# Altium schematic sheet JacdacNRF52833HighPower 32.SchDoc
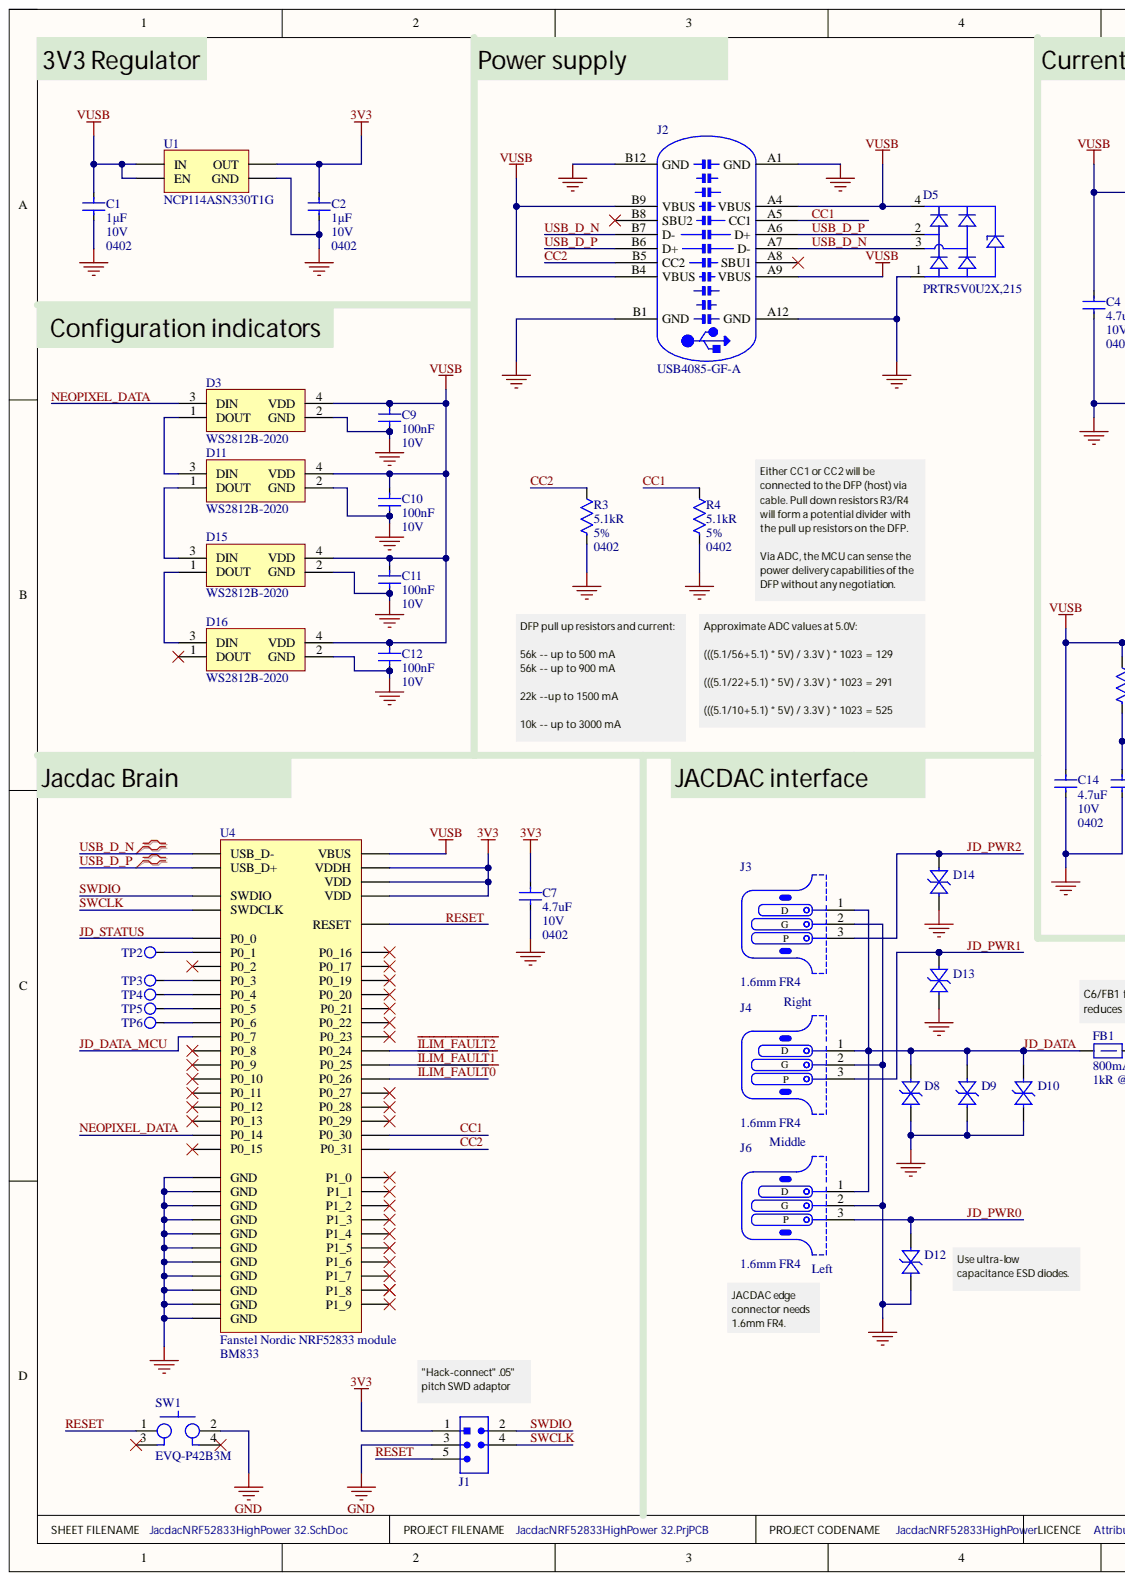
[diagram p0: JacdacNRF52833HighPower 32.SchDoc, left]
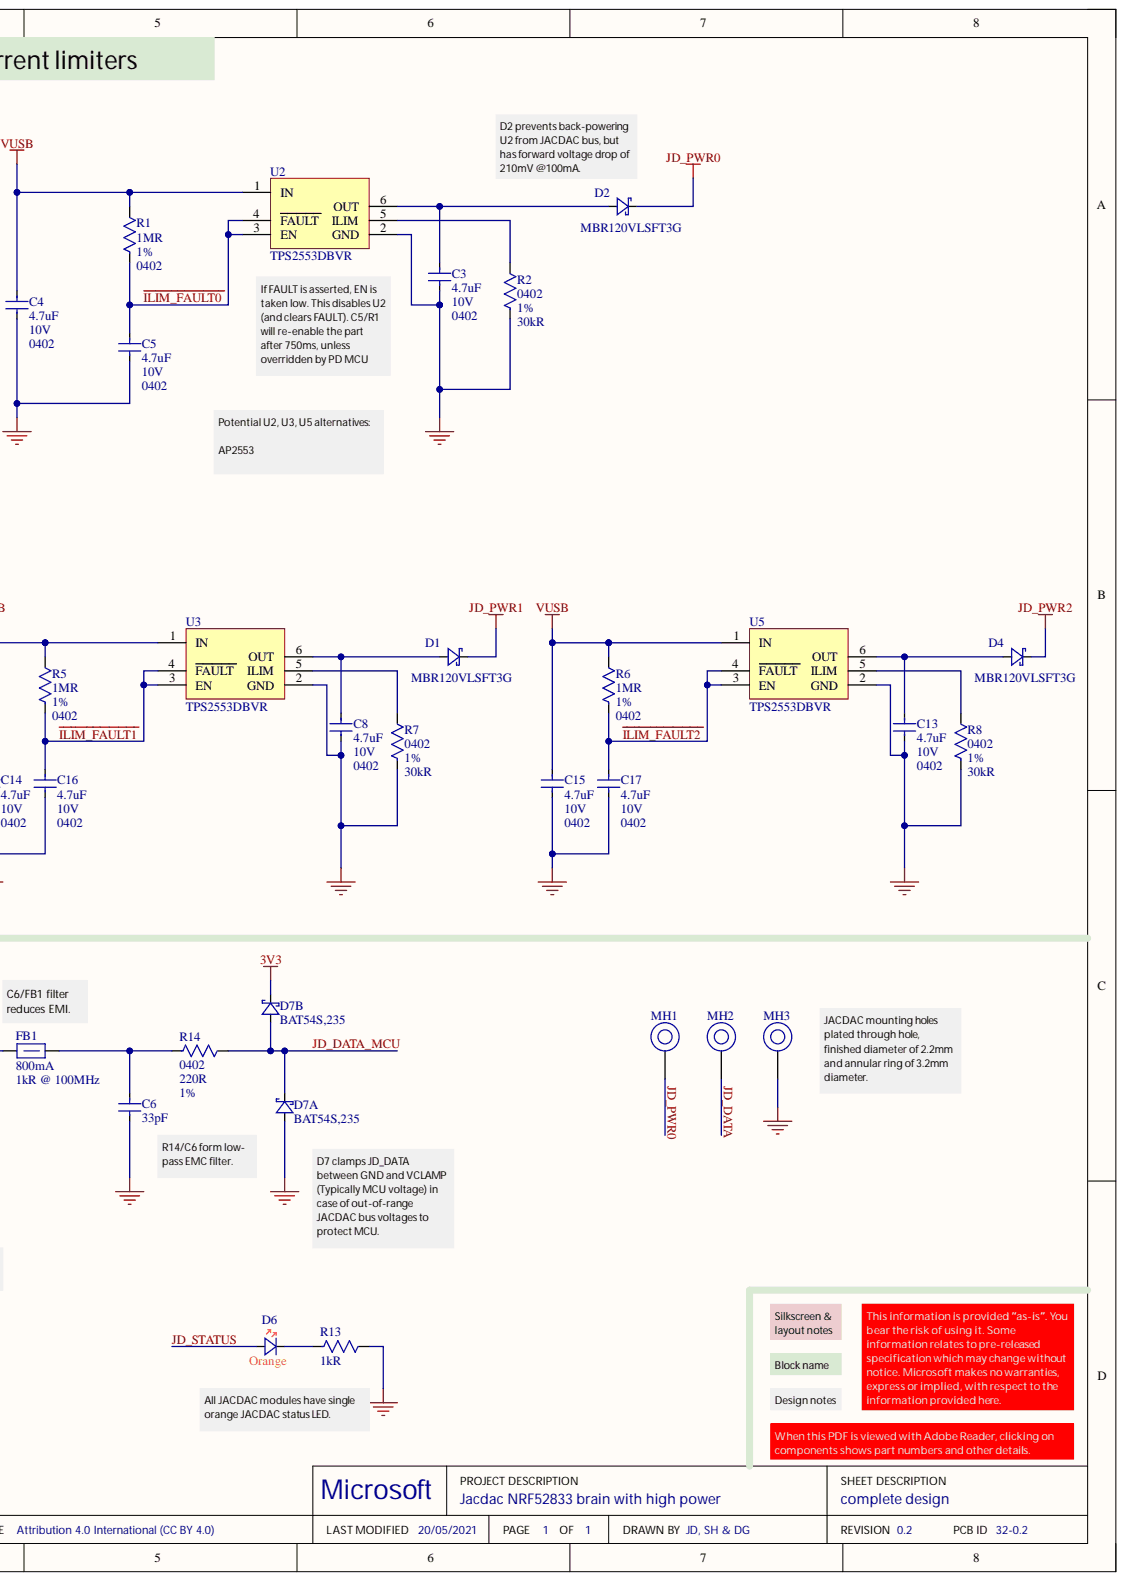
[diagram p1: JacdacNRF52833HighPower 32.SchDoc, right]

## Parts
C1  Capacitance: 1µF  Case Code (Imperial): 0402  Voltage Rating: 10V  [C0402_0.55MM - without outline]  (hidden comment: CL05A105KP5NNNC)
C2  Capacitance: 1µF  Case Code (Imperial): 0402  Voltage Rating: 10V  [C0402_0.55MM - without outline]  (hidden comment: CL05A105KP5NNNC)
C3  Capacitance: 4.7uF  Case Code (Imperial): 0402  Voltage Rating: 10V  [R0402 - Without outline]  (hidden comment: CL05A475MP5NRNC)
C4  Capacitance: 4.7uF  Case Code (Imperial): 0402  Voltage Rating: 10V  [R0402 - Without outline]  (hidden comment: CL05A475MP5NRNC)
C5  Capacitance: 4.7uF  Case Code (Imperial): 0402  Voltage Rating: 10V  [R0402 - Without outline]  (hidden comment: CL05A475MP5NRNC)
C6  Capacitance: 33pF  [C0402_0.55MM - without outline]  (hidden comment: CL05C330JB51PNC)
C7  Capacitance: 4.7uF  Case Code (Imperial): 0402  Voltage Rating: 10V  [R0402 - Without outline]  (hidden comment: CL05A475MP5NRNC)
C8  Capacitance: 4.7uF  Voltage Rating: 10V  [R0402 - Without outline]  (hidden comment: CL05A475MP5NRNC)
C9  Capacitance: 100nF  Voltage Rating: 10V  [C0402_0.55MM - without outline]  (hidden comment: CL05B104KP5NNNC)
C10  Capacitance: 100nF  Voltage Rating: 10V  [C0402_0.55MM - without outline]  (hidden comment: CL05B104KP5NNNC)
C11  Capacitance: 100nF  Voltage Rating: 10V  [C0402_0.55MM - without outline]  (hidden comment: CL05B104KP5NNNC)
C12  Capacitance: 100nF  Voltage Rating: 10V  [C0402_0.55MM - without outline]  (hidden comment: CL05B104KP5NNNC)
C13  Capacitance: 4.7uF  Voltage Rating: 10V  [R0402 - Without outline]  (hidden comment: CL05A475MP5NRNC)
C14  Capacitance: 4.7uF  Case Code (Imperial): 0402  Voltage Rating: 10V  [R0402 - Without outline]  (hidden comment: CL05A475MP5NRNC)
C15  Capacitance: 4.7uF  Case Code (Imperial): 0402  Voltage Rating: 10V  [R0402 - Without outline]  (hidden comment: CL05A475MP5NRNC)
C16  Capacitance: 4.7uF  Case Code (Imperial): 0402  Voltage Rating: 10V  [R0402 - Without outline]  (hidden comment: CL05A475MP5NRNC)
C17  Capacitance: 4.7uF  Case Code (Imperial): 0402  Voltage Rating: 10V  [R0402 - Without outline]  (hidden comment: CL05A475MP5NRNC)
D1  MBR120VLSFT3G  [MBR120VLSFT3G]
D2  MBR120VLSFT3G  [MBR120VLSFT3G]
D3  WS2812B-2020  [WS2812B-2020]
D4  MBR120VLSFT3G  [MBR120VLSFT3G]
D5  Manufacturer Part Number: PRTR5V0U2X,215  [NXP-SOT143B-4_V]  (hidden comment: NXP-PRTR5V0U2X,215-4-whitesch)
D6  Illumination Color: Orange  [LTST-S220KFKT]  (hidden comment: Right angle mount LED (orange))
D7  BAT54S  Manufacturer Part Number: BAT54S,235  [NXP-BAT54S-TO-236AB]  (parts A,B)
D8  VBUS05B1-SD0-G4-08  [0201-VBUS05B1-SD0-G4-08 - Without outline]  (value not drawn)
D9  VBUS05B1-SD0-G4-08  [0201-VBUS05B1-SD0-G4-08 - Without outline]  (value not drawn)
D10  VBUS05B1-SD0-G4-08  [0201-VBUS05B1-SD0-G4-08 - Without outline]  (value not drawn)
D11  WS2812B-2020  [WS2812B-2020]
D12  VBUS05B1-SD0-G4-08  [0201-VBUS05B1-SD0-G4-08 - Without outline]  (value not drawn)
D13  VBUS05B1-SD0-G4-08  [0201-VBUS05B1-SD0-G4-08 - Without outline]  (value not drawn)
D14  VBUS05B1-SD0-G4-08  [0201-VBUS05B1-SD0-G4-08 - Without outline]  (value not drawn)
D15  WS2812B-2020  [WS2812B-2020]
D16  WS2812B-2020  [WS2812B-2020]
FB1  Current Rating: 800mA  Impedance: 1kR @ 100MHz  [TDK MPZ1608 0.8mm - without outline]  (hidden comment: TDK MPZ1608S102ATA00)
J1  Hack Connect Mini 1.27 mm pitch 2-row  [HACK_CONNECT_MINI_5_X_1_27]  (value not drawn)  (no BOM)
J2  USB4085-GF-A  [USB4085-GF-A]
J3  JACDAC edge connector  PCB Type: 1.6mm FR4  [JACDAC_EDGE_CONNECTOR_0.2mm_T&G]  (no BOM)
J4  JACDAC edge connector  PCB Type: 1.6mm FR4  [JACDAC_EDGE_CONNECTOR_0.2mm_T&G]  (no BOM)
J6  JACDAC edge connector  PCB Type: 1.6mm FR4  [JACDAC_EDGE_CONNECTOR_0.2mm_T&G]  (no BOM)
MH1  MH_5.0MMK_3.0MMC_2.1MMD_PTH  [MH_5.0MMK_3.0MMC_2.1MMD_PTH]  (value not drawn)  (no BOM)
MH2  MH_5.0MMK_3.0MMC_2.1MMD_PTH  [MH_5.0MMK_3.0MMC_2.1MMD_PTH]  (value not drawn)  (no BOM)
MH3  MH_5.0MMK_3.0MMC_2.1MMD_PTH  [MH_5.0MMK_3.0MMC_2.1MMD_PTH]  (value not drawn)  (no BOM)
R1  Case Code (Imperial): 0402  Resistance: 1MR  Resistance Tolerance: 1%  [R0402 - Without outline]
R2  Case Code (Imperial): 0402  Resistance: 30kR  Resistance Tolerance: 1%  [R0402]  (hidden comment: 0402 RES SMD 30k 1%)
R3  Case Code (Imperial): 0402  Resistance: 5.1kR  Resistance Tolerance: 5%  [R0402 - Without outline]  (hidden comment: RC0402JR-075K1L)
R4  Case Code (Imperial): 0402  Resistance: 5.1kR  Resistance Tolerance: 5%  [R0402 - Without outline]  (hidden comment: RC0402JR-075K1L)
R5  Case Code (Imperial): 0402  Resistance: 1MR  Resistance Tolerance: 1%  [R0402 - Without outline]
R6  Case Code (Imperial): 0402  Resistance: 1MR  Resistance Tolerance: 1%  [R0402 - Without outline]
R7  Case Code (Imperial): 0402  Resistance: 30kR  Resistance Tolerance: 1%  [R0402]  (hidden comment: 0402 RES SMD 30k 1%)
R8  Case Code (Imperial): 0402  Resistance: 30kR  Resistance Tolerance: 1%  [R0402]  (hidden comment: 0402 RES SMD 30k 1%)
R13  Resistance: 1kR  [R0402 - Without outline]  (hidden comment: RC0402FR-071KL)
R14  Case Code (Imperial): 0402  Resistance: 220R  Tolerance: 1%  [R0402 - Without outline]  (hidden comment: RC0402FR-07220RL)
SW1  Side mount digital switch.  [EVQ-P42B3M]  (value not drawn)
TP2  TestPoint  [Pad1.27mm]  (value not drawn)  (no BOM)
TP3  TestPoint  [Pad1.27mm]  (value not drawn)  (no BOM)
TP4  TestPoint  [Pad1.27mm]  (value not drawn)  (no BOM)
TP5  TestPoint  [Pad1.27mm]  (value not drawn)  (no BOM)
TP6  TestPoint  [Pad1.27mm]  (value not drawn)  (no BOM)
U1  LDO 3.3V/300 mA  [ON_SEMI-SOT23-5]  (value not drawn)
U2  Manufacturer Part Number 1: TPS2553DBVR  [TI-TPS2553]  (hidden comment: TPS2553DBVR_ALT)
U3  Manufacturer Part Number 1: TPS2553DBVR  [TI-TPS2553]  (hidden comment: TPS2553DBVR_ALT)
U4  Fanstel Nordic NRF52833 module  Manufacturer 1: Fanstel  [BM833]
U5  Manufacturer Part Number 1: TPS2553DBVR  [TI-TPS2553]  (hidden comment: TPS2553DBVR_ALT)

## Nets
3V3: C2.2 C7.2 D7.2 J1.1 U1.5(OUT) U4.14(VDD) U4.28(VDD) U4.56(VDDH)
GND: C1.1 C2.1 C3.1 C4.1 C5.1 C6.1 C7.1 C8.1 C9.1 C10.1 C11.1 C12.1 C13.1 C14.1 C15.1 C16.1 C17.1 D3.2(GND) D5.1 D7.1 D8.2 D9.2 D10.2 D11.2(GND) D12.2 D13.2 D14.2 D15.2(GND) D16.2(GND) J1.3 J2.A1(GND) J2.A12(GND) J2.B1(GND) J2.B12(GND) J3.2 J4.2 J6.2 MH3.1 R2.1 R3.2 R4.2 R7.1 R8.1 R13.1 SW1.2 U1.2(GND) U2.2(GND) U3.2(GND) U4.1(GND) U4.2(GND) U4.3(GND) U4.4(GND) U4.5(GND) U4.13(GND) U4.15(GND) U4.18(GND) U4.19(GND) U4.30(GND) U4.45(GND) U5.2(GND)
VUSB: C1.2 C4.2 C9.2 C10.2 C11.2 C12.2 C14.2 C15.2 D3.4(VDD) D5.4 D11.4(VDD) D15.4(VDD) D16.4(VDD) J2.A4(VBUS) J2.A9(VBUS) J2.B4(VBUS) J2.B9(VBUS) R1.1 R5.1 R6.1 U1.1(IN) U1.3(EN) U2.1(IN) U3.1(IN) U4.58(VBUS) U5.1(IN)
~{ILIM_FAULT0}: C5.2 R1.2 U2.3(EN) U2.4(FAULT) U4.7(P0_26)
~{ILIM_FAULT1}: C16.2 R5.2 U3.3(EN) U3.4(FAULT) U4.55(P0_25)
~{ILIM_FAULT2}: C17.2 R6.2 U4.47(P0_24) U5.3(EN) U5.4(FAULT)
CC1: J2.A5(CC1) R4.1 U4.11(P0_30)
CC2: J2.B5(CC2) R3.1 U4.12(P0_31)
JD_DATA: D8.1 D9.1 D10.1 FB1.1 J3.1 J4.1 J6.1 MH2.1
JD_DATA_MCU: D7.3 R14.1 U4.24(P0_7)
JD_PWR0: D2.1 D12.1 J6.3 MH1.1
JD_PWR1: D1.1 D13.1 J4.3
JD_PWR2: D4.1 D14.1 J3.3
JD_STATUS: D6.2 U4.16(P0_0)
NEOPIXEL_DATA: D3.3(DIN) U4.33(P0_14)
RESET: J1.5 SW1.1 U4.40(RESET)
SWCLK: J1.4 U4.42(SWDCLK)
SWDIO: J1.2 U4.43(SWDIO)
USB_D_N: D5.3 J2.A7(D-) J2.B7(D-) U4.68(USB_D-)
USB_D_P: D5.2 J2.A6(D+) J2.B6(D+) U4.67(USB_D+)
NetC3_2: C3.2 D2.2 U2.6(OUT)
NetC6_2: C6.2 FB1.2 R14.2
NetC8_2: C8.2 D1.2 U3.6(OUT)
NetC13_2: C13.2 D4.2 U5.6(OUT)
NetD3_1: D3.1(DOUT) D11.3(DIN)
NetD6_1: D6.1 R13.2
NetD11_1: D11.1(DOUT) D15.3(DIN)
NetD15_1: D15.1(DOUT) D16.3(DIN)
NetR2_2: R2.2 U2.5(ILIM)
NetR7_2: R7.2 U3.5(ILIM)
NetR8_2: R8.2 U5.5(ILIM)
NetTP2_1: TP2.1 U4.17(P0_1)
NetTP3_1: TP3.1 U4.20(P0_3)
NetTP4_1: TP4.1 U4.21(P0_4)
NetTP5_1: TP5.1 U4.23(P0_5)
NetTP6_1: TP6.1 U4.25(P0_6)

Not connected: D16 1(DOUT); J2 A8(SBU1) B8(SBU2); SW1 3 4; U4 8(P0_27) 9(P0_28) 10(P0_29) 22(P0_2) 26(P0_9) 27(P0_8) 29(P0_10) 31(P1_1) 32(P1_2) 34(P0_11) 35(P0_15) 36(P0_16) 37(P0_17) 38(P1_0) 41(P0_13) 44(P0_22) 48(P0_19) 49(P1_3) 51(P1_5) 52(P1_9) 53(P0_23) 54(P1_8) 60(P0_21) 61(P1_4) 62(P0_20) 64(P0_12) 65(P1_6) 66(P1_7)

## Library data of the parts
C1 C2 = CL05A105KP5NNNC: CAP CER 1UF 10V X5R 0402; Manufacturer: Samsung; Material: Ceramic; Tolerance: 10%; Case Code (Metric): 1005; Case/Package: 0402; Category: Capacitors; Component Kind: Standard; ComponentLink1Description: Online datasheet; ComponentLink1URL: https://datasheet.ciiva.com/15195/getdatasheetpartid-358390-15195630.pdf; Contact Plating: Tin; Depth: 0.5mm; Dielectric: X5R; Height: 0.5mm; Length: 1mm; Library Name: Samsung.SchLib; Manufacturer Part Number: CL05A105KP5NNNC; Max Operating Temperature: 85°C; Min Operating Temperature: -55°C; Mount: Surface Mount; Package Quantity: 1; Packaging: Tape and Reel; Pricing 1: 1=0.10000, 10=0.03900, 50=0.02100, 100=0.01720, 500=0.01228, 1000=0.00965, 2500=0.00877, 5000=0.00807 (USD); Pricing 2: 1=0.10000, 10=0.04200, 100=0.01800, 500=0.01300, 1000=0.01000, 2500=0.00900 (USD); Pricing 3: 10=0.02450, 100=0.02000, 500=0.01310, 2500=0.00920, 5000=0.00810, 10000=0.00640, 50000=0.00490 (GBP); Radiation Hardening: No; RoHS: Yes; Stock 1: 5705569; Stock 2: 928941; Stock 3: 53670; Supplier 1: Digi-Key; Supplier 2: Mouser; Supplier 3: Farnell; Supplier Part Number 1: 1276-1076-1-ND; Supplier Part Number 2: 187-CL05A105KP5NNNC; Supplier Part Number 3: 3013329; Thickness: 0.022inch; Width: 0.02inch; Checked: ?; Created: DG
C3-C5 C7 C8 C13-C17 = CL05A475MP5NRNC: CAP CER 4.7UF 10V X5R 0402; Manufacturer: Samsung; Tolerance: 20%; Case Code (Metric): 1005; Case/Package: 0402; Category: Ceramic Capacitors; Component Kind: Standard; ComponentLink1Description: Online datasheet; ComponentLink1URL: https://datasheet.ciiva.com/25786/cl05a475mp5nrnc-25786963.pdf; Dielectric: X5R; Lead Free: Lead Free; Length: 0.039inch; Library Name: Samsung.SchLib; Manufacturer Part Number: CL05A475MP5NRNC; Max Operating Temperature: 85°C; Min Operating Temperature: -55°C; Mount: Surface Mount; Package Quantity: 10000; Packaging: Tape and Reel; Pricing 1: 10000=0.01405, 20000=0.01338 (USD); Pricing 2: 1=0.23500, 500=0.03970, 1500=0.02900, 2500=0.02500, 5000=0.02050 (USD); RoHS: Yes; RoHS Compliant: Yes; Series: CL; Stock 1: 840000; Stock 2: 2004; Supplier 1: Digi-Key; Supplier 2: Future Electronics; Supplier Part Number 1: 1276-1482-2-ND; Supplier Part Number 2: 2103694; Thickness: 0.022inch; Width: 0.02inch; Checked By: ?; Created By: D. Gakure
  C8 C13: Case Code (Imperial): 0402
C6 = CL05C330JB51PNC: CAP CER 33PF 50V NP0 0402; Manufacturer: Samsung; Tolerance: 5%; Case Code (Imperial): 0402; Case Code (Metric): 1005; Case/Package: 0402; Category: Capacitors; Component Kind: Standard; ComponentLink1Description: Samsung datasheet online; ComponentLink1URL: http://www.samsungsem.com/kr/support/library/product-catalog/mlcc.jsp; Lead Free: Lead Free; Length: 0.039inch; Library Name: Samsung.SchLib; Manufacturer Part Number: CL05C330JB51PNC; Max Operating Temperature: 125°C; Min Operating Temperature: -55°C; Mount: Surface Mount; Packaging: Tape and Reel; Pricing 1: 1=0.10000, 10=0.07500, 50=0.04060, 100=0.03320, 500=0.02374, 1000=0.01865, 2500=0.01696, 5000=0.01560 (USD); Pricing 2: 1=0.11000, 10=0.08200, 100=0.03600, 500=0.02600, 1000=0.02000, 2500=0.01800 (USD); Pricing 3: 10000=0.00780 (USD); Ratings: AEC-Q200; RoHS: Yes; Stock 1: 250654; Stock 2: 97900; Stock 3: 100000; Supplier 1: Digi-Key; Supplier 2: Mouser; Supplier 3: Future Electronics; Supplier Part Number 1: 1276-6515-1-ND; Supplier Part Number 2: 187-CL05C330JB51PNC; Supplier Part Number 3: 6022520; Thickness: 0.022inch; Voltage Rating: 50V; Width: 0.02inch; Checked: ?; Created: DG
C9-C12 = CL05B104KP5NNNC: CAP CER 0.1UF 10V X7R 0402; Manufacturer: Samsung; Tolerance: 10%; Case Code (Imperial): 0402; Case Code (Metric): 1005; Case/Package: 0402; Category: Ceramic Capacitors; Component Kind: Standard; ComponentLink1Description: Samsung datasheet online; ComponentLink1URL: https://datasheet.ciiva.com/15165/getdatasheetpartid-37100-15165107.pdf; Contact Plating: Tin; Depth: 0.5mm; Dielectric: X7R; Height: 0.5mm; Length: 1mm; Library Name: Samsung.SchLib; Manufacturer Part Number: CL05B104KP5NNNC; Max Operating Temperature: 125°C; Min Operating Temperature: -55°C; Mount: Surface Mount; Package Quantity: 1; Packaging: Tape and Reel; Pricing 1: 1=0.10000, 10=0.02500, 50=0.01380, 100=0.01130, 500=0.00804, 1000=0.00632, 2500=0.00575, 5000=0.00529 (USD); Pricing 2: 10000=0.00400, 20000=0.00400, 50000=0.00400 (USD); Pricing 3: 10=0.01620, 100=0.01310, 500=0.00740, 2500=0.00600, 5000=0.00470, 10000=0.00400, 50000=0.00320 (GBP); Pricing 4: 1=0.09000, 25=0.02300, 100=0.01000, 250=0.00900, 500=0.00700, 1000=0.00500, 2500=0.00500 (USD); Pricing 5: 1=0.00460, 500=0.00340, 1500=0.00320, 2500=0.00310, 5000=0.00300 (USD); Radiation Hardening: No; RoHS: Yes; Stock 1: 17321183; Stock 2: 984124; Stock 3: 39022; Stock 4: 56730; Stock 5: 20877033; Supplier 1: Digi-Key; Supplier 2: Mouser; Supplier 3: Farnell; Supplier 4: Newark; Supplier 5: Future Electronics; Supplier Part Number 1: 1276-1002-1-ND; Supplier Part Number 2: 187-CL05B104KP5NNNC; Supplier Part Number 3: 3013351; Supplier Part Number 4: 82AC9219; Supplier Part Number 5: 9032525; Thickness: 0.022inch; Width: 0.02inch; Checked: ?; Created: DG
D1 D2 D4 = MBR120VLSFT3G: DIODE SCHOTTKY 20V 1A SOD123FL; Manufacturer: ON Semiconductor; Element Configuration: Single; Forward Voltage: 340mV; Max Forward Surge Current (Ifsm): 45A; Max Reverse Leakage Current: 600uA; Polarity: Standard; Average Rectified Current: 1A; Category: Schottky Diodes; Component Kind: Standard; ComponentLink4Description: On Semi datasheet online; ComponentLink4URL: https://datasheet.ciiva.com/6380/mbr120vlsft1-d-6380910.pdf; Current Rating: 1A; Forward Current: 1A; Halogen Free: Halogen Free; Lead Free: Lead Free; Library Name: OnSemiconductor.SchLib; Manufacturer Part Number: MBR120VLSFT3G; Max Operating Temperature: 125°C; Max Repetitive Reverse Voltage (Vrrm): 20V; Max Reverse Voltage (DC): 20V; Max Surge Current: 45A; Min Operating Temperature: -65°C; Number of Pins: 2; Output Current: 1A; Package Quantity: 10000; Packaging: Tape and Reel; Peak Non-Repetitive Surge Current: 45A; Peak Reverse Current: 600uA; Pricing 1: 1=0.39000, 10=0.28600, 100=0.19920, 500=0.12616, 1000=0.10292, 2000=0.09960, 5000=0.09628 (USD); Radiation Hardening: No; REACH SVHC: No SVHC; RoHS: Yes; Stock 1: 32481; Supplier 1: Digi-Key; Supplier 2: Mouser; Supplier 3: RSComponents; Supplier Part Number 1: MBR120VLSFT3GOSCT-ND; Supplier Part Number 2: 863-MBR120VLSFT3G; Supplier Part Number 3: 1867479; Voltage Rating (DC): 20V
D3 D11 D15 D16 = WS2812B-2020: WS2812B RGB LED (Neopixel) 2.0mm x 2.0mm; VDD: 3.7-5.3; Vih: 2.7; Vil: -0.3; Component Kind: Standard; ComponentLink1Description: datasheet (offline); ComponentLink2Description: datasheet (online); ComponentLink2URL: https://datasheet.lcsc.com/lcsc/2012110135_Worldsemi-WS2812B-2020_C965555.pdf; Manufacturer Part Number: WS2812B-2020; ComponentLink1URL: Y:\System\Altium\Libraries 2014\WorldSemi\Datasheets\Worldsemi_WS2812B-2020.pdf; Library Name: WorldSemi.SchLib
D5 = NXP-PRTR5V0U2X,215-4-whitesch: USB TVS and ESD protection diodes; Manufacturer: NXP Semiconductors; Breakdown Voltage: 6V; Capacitance: 16pF; Direction: Unidirectional; ESD Protection: Yes; Leakage Current: 100nA; Max Breakdown Voltage: 9V; Max Reverse Leakage Current: 100uA; Number of Bidirectional Channels: 2; Number of Elements: 1; Polarity: Bidirectional,Unidirectional; Reverse Standoff Voltage: 5.5V; Case/Package: SOT; Component Kind: Standard; ComponentLink8Description: NXP datasheet; ComponentLink8URL: http://www.farnell.com/datasheets/109718.pdf?src-supplier=Farnell; Contact Plating: Tin; Depth: 1.4mm; Height: 1.1mm; Lead Free: Lead Free; Length: 3mm; Library Name: NXP.SchLib; Max Operating Temperature: 85°C; Min Breakdown Voltage: 6V; Min Operating Temperature: -40°C; Mount: Surface Mount; Number of Channels: 2; Number of Pins: 4; Package Quantity: 1; Packaging: Tape and Reel; Power Line Protection: Yes; Pricing 1: 1=0.55, 10=0.47, 100=0.3507, 500=0.27552, 1000=0.2129 (USD); Radiation Hardening: No; REACH SVHC: No SVHC; Reverse Breakdown Voltage: 6V; Stock 1: 109808; Supplier 1: Digi-Key; Supplier 2: Farnell; Supplier 3: RSComponents; Supplier 4: Mouser; Supplier Part Number 1: 1727-3884-1-ND; Supplier Part Number 2: 2371119; Supplier Part Number 3: 725-8720; Supplier Part Number 4: 771-PRTR5V0U2X-T/R; Termination: SMD/SMT; Weight: 0.001lb; Width: 1.4mm; Working Voltage: 5.5V
D6 = LTST-S220KFKT: LED ORANGE CLEAR SMD R/A; Manufacturer 1: Vishay Lite-On; Color: Orange,Yellow; Dominant Wavelength: 605nm; Forward Current: 20mA; Forward Voltage: 2V; Lens Color: Colorless; Lens Style: Clear,Diffused,Colorless,Rectangular; Luminous Intensity: 90mcd; Number of Elements: 1; Power Dissipation: 75mW; Reverse Voltage: 5V; Viewing Angle: 130°; Wavelength: 611nm; Case/Package: SMD/SMT; Category: LEDs; Component Kind: Standard; ComponentLink1Description: datasheet (online); ComponentLink1URL: https://optoelectronics.liteon.com/upload/download/DS22-2000-136/LTST-S220KFKT.pdf; ComponentLink2Description: datasheet (offline); Depth: 0.6mm; Height: 0.6mm; Length: 2.1mm; Lens Transparency: Clear; Library Name: Lite-On.SchLib; Manufacturer Part Number 1: LTST-S220KFKT; Max Operating Temperature: 85°C; Min Operating Temperature: -55°C; Mount: Surface Mount; Number of LEDs: 1; Number of Pins: 2; Package Quantity: 4000; Packaging: Tape and Reel; Power Rating: 75mW; Pricing 1: 1=0.23000, 10=0.12400, 100=0.05750, 500=0.05310, 1000=0.04160, 2000=0.04071 (USD); Radiation Hardening: No; RoHS: Yes; RoHS Compliant: Yes; Series: -; Stock 1: 4947; Supplier 1: Digi-Key; Supplier 2: RSComponents; Supplier 3: Mouser; Supplier Part Number 1: 160-2031-1-ND; Supplier Part Number 2: 6921186P; Supplier Part Number 3: 859-LTST-S220KFKT; Test Current: 20mA; Width: 1mm; ComponentLink2URL: Y:\System\Altium\Libraries 2014\Lite-On\datasheets\LTST-S220KFKT.pdf
D7 = BAT54S: DIODE ARRAY SCHOTTKY 30V SOT23; Manufacturer: Nexperia; Category: Rectifier Diodes; Component Kind: Standard; ComponentLink1Description: NXP datasheet online; ComponentLink1URL: https://assets.nexperia.com/documents/data-sheet/BAT54_SER.pdf; Library Name: NXP.SchLib; Pricing 1: 1=0.13000, 10=0.11900, 100=0.05610, 500=0.03326, 1000=0.02185, 5000=0.02138 (USD); RoHS: No; Stock 1: 14011; Supplier 1: Digi-Key; Supplier 2: Mouser; Supplier 3: RSComponents; Supplier Part Number 1: 1727-1868-1-ND; Supplier Part Number 2: 771-BAT54S235; Supplier Part Number 3: 1707990
D8-D10 D12-D14 = VBUS05B1-SD0-G4-08: TVS DIODE 5.5V 18V CLP0603; Manufacturer: Vishay Semiconductors; Capacitance: 0.4pF; Direction: Bidirectional; Element Configuration: Single; Min Breakdown Voltage: 6V; Number of Bidirectional Channels: 1; Peak Pulse Current: 2.5A; Peak Pulse Power: 45W; Power Line Protection: No; Case Code (Imperial): 0201; Case Code (Metric): 0603; Case/Package: 0201; Category: TVS Diodes; Clamping Voltage: 18V; Component Kind: Standard; ComponentLink2Description: Online datasheet; ComponentLink2URL: http://www.vishay.com/docs/85899/vbus05b1-sd0.pdf; Library Name: Vishay.schlib; Manufacturer Part Number: VBUS05B1-SD0-G4-08; Max Operating Temperature: 150°C; Min Operating Temperature: -55°C; Mount: Surface Mount; Number of Pins: 2; Packaging: Tape and Reel; Pricing 1: 1=0.27000, 10=0.18500, 100=0.09020, 500=0.07524, 1000=0.05228, 2000=0.04531, 5000=0.04203 (USD); Pricing 2: 5=0.20800, 10=0.14000, 100=0.06340, 500=0.05020, 1000=0.04160, 5000=0.03300 (GBP); Pricing 3: 1=0.27000, 10=0.18500, 50=0.18500, 100=0.09300, 1000=0.03900, 10000=0.03400 (USD); Pricing 4: 1=0.17630, 10=0.14370, 25=0.14230, 100=0.07810, 250=0.07660, 500=0.06310, 15000=0.02980, 150000=0.02970, 1500000=0.02870, 7500000=0.02800 (USD); Resistor Type: Zener; Reverse Standoff Voltage: 5.5V; RoHS: Yes; Stock 1: 22028; Stock 2: 13100; Stock 3: 1209; Stock 4: 719; Supplier 1: Digi-Key; Supplier 2: Farnell; Supplier 3: Mouser; Supplier 4: Arrow Electronics; Supplier Part Number 1: VBUS05B1-SD0-G4-08GICT-ND; Supplier Part Number 2: 2932858; Supplier Part Number 3: 78-VBUS05B1SD0-G4-08; Supplier Part Number 4: VBUS05B1-SD0-G4-08; Working Voltage: 5.5V; Checked By: ?; Created By: D. Gakure
FB1 = TDK MPZ1608S102ATA00: 0603 1k@100MHz 0.8A chip; Core Material: Ferrite; DC Resistance (DCR): 300mR; Tolerance: 25%; Case Code (Imperial): 0603; Case Code (Metric): 1608; Case/Package: 0603; Component Kind: Standard; ComponentLink2Description: datasheet online; ComponentLink2URL: https://www.mouser.co.uk/datasheet/2/400/eads_commercial_power_mpz1608_en-923650.pdf; ComponentLink3Description: datasheet; Height: 0.037inch; Length: 0.063inch; Library Name: TDK.SchLib; Manufacturer: TDK; Manufacturer Part Number: MPZ1608S102ATA00; Max DC Current: 800mA; Mount: Surface Mount; Package Quantity: 4000; Packaging: Tape and Reel; Pricing 1: 1=0.1, 10=0.079, 25=0.0716, 50=0.0644, 100=0.0472, 250=0.03432, 500=0.03146, 1000=0.02717 (USD); Stock 1: 113542; Supplier 1: Digi-Key; Supplier 2: Mouser; Supplier Part Number 1: 445-8694-1-ND; Supplier Part Number 2: 810-MPZ1608S102ATA00; Width: 0.031inch; ComponentLink3URL: Y:\System\Altium\Libraries 2014\TDK\Datasheets\eads_commercial_power_mpz1608_en-923650.pdf
J1 = HACK_CONNECT_MINI: Description: Hack Connect Mini 1.27 mm pitch 2-row; Library Name: generic-con.SchLib
J2 = USB4085-GF-A: USB4085 Series 20 V PCB Top Mount USB 2.0 Type C Receptacle; Manufacturer 1: Global Connector Technology; Number of Positions: 16; USB Standard: USB 2.0; Category: USB Connectors; Component Kind: Standard; ComponentLink2Description: Datasheet (online); ComponentLink2URL: https://datasheet.ciiva.com/71788/usb4085-71788147.pdf?src-supplier=Digi-Key; Contact Material: Copper Alloy; Contact Plating: Gold; Gender: Receptacle; Manufacturer Part Number 1: USB4085-GF-A; Orientation: Right Angle; Pricing 1: 1=1.340000, 10=1.178000, 25=1.108800, 50=1.062600, 100=1.016400, 250=0.924000 (USD); RoHS: Yes; Stock 1: 17632; Supplier 1: Digi-Key; Supplier 2: Farnell; Supplier Part Number 1: 2073-USB4085-GF-ACT-ND; Supplier Part Number 2: 2924867; Library Name: GCT.SchLib
J3 J4 J6 = JACDAC edge connector: 3 pin jacdac edge connector 1.27mm pitch; Manufacturer Part Number: JACDAC_EDGE_CONNECTOR; ComponentLink1Description: Yuliang JACDAC cable connector drawing online; ComponentLink1URL: https://github.com/microsoft/jacdac-mdk/blob/main/connector/JACDAC-Connector-by-Yuliang-2020-11-28.pdf; ComponentLink2Description: JACDAC PCB edge connector spec onlin; ComponentLink2URL: https://github.com/microsoft/jacdac-mdk/blob/main/connector/JACDAC_PCB_Edge_Connector_Drawing_JD-PEC-01_rev_01.pdf; Library Name: generic-con.SchLib; Checked By: ?; Created By: D. Gakure
MH1-MH3 = MH_5.0MMK_3.0MMC_2.1MMD_PTH: Mounting Hole 5.0mm keepout, 3.0mm annualar ring and 2.1mm Drill PTH; Library Name: generic.SchLib
R1 R5 R6 = RC0402FR-071ML: RES SMD 1M OHM 1% 1/16W 0402; Manufacturer: Yageo; Max Power Dissipation: 63mW; Temperature Coefficient: 100ppm/°C; Voltage Rating: 50V; Case Code (Metric): 1005; Case/Package: 0402; Category: Chip SMD Resistors; Component Kind: Standard; ComponentLink1Description: Online datasheet; ComponentLink1URL: https://datasheet.ciiva.com/11808/0900766b80c66297-11808125.pdf; Construction: Rectangular; Depth: 0.5mm; Features: Moisture Resistant; Height: 0.32mm; Lead Free: Lead Free; Length: 1mm; Library Name: Yageo.SchLib; Manufacturer Part Number: RC0402FR-071ML; Max Operating Temperature: 155°C; Military Standard: Not; Min Operating Temperature: -55°C; Number of Terminations: 2; Packaging: Tape and Reel; Power Rating: 63mW; Pricing 1: 1=0.10000, 10=0.01600, 100=0.00640, 1000=0.00286, 2500=0.00248, 5000=0.00205 (USD); Pricing 2: 1=0.10000, 10=0.01200, 50=0.01200, 100=0.00400, 1000=0.00400, 10000=0.00300 (USD); Pricing 3: 10000=0.00290, 50000=0.00200 (GBP); REACH SVHC: Unknown; Resistor Type: Thick Film; RoHS: Yes; RoHS Compliant: Yes; Series: RC; Size Code: 0402; Stock 1: 7856490; Stock 2: 1194490; Stock 3: 1530000; Supplier 1: Digi-Key; Supplier 2: Mouser; Supplier 3: Farnell; Supplier Part Number 1: 311-1.00MLRCT-ND; Supplier Part Number 2: 603-RC0402FR-071ML; Supplier Part Number 3: 2421892; Technology: THICK FILM; Termination: SMD/SMT; Tolerance: 1%; Checked By: ?; Created By: D. Gakure
R2 R7 R8 = RC0402FR-0730KL: YAGEO - RC0402FR-0730KL - RES, 30K, 1%, 0.063W, 0402, THICK FILM; Max Power Dissipation: 63mW; Power Rating: 62.5mW; Temperature Coefficient: 100ppm/°C; Voltage Rating: 50V; Case Code (Metric): 1005; Case/Package: 0402; Category: Chip SMD Resistors; Component Kind: Standard; ComponentLink1Description: https://datasheet.ciiva.com/7849/1018594-7849957.pdf?src-supplier=Element14; ComponentLink1URL: https://datasheet.ciiva.com/7849/1018594-7849957.pdf?src-supplier=Element14; ComponentLink2Description: https://datasheet.ciiva.com/46394/1018594-46394938.pdf?src-supplier=Element14; ComponentLink2URL: https://datasheet.ciiva.com/46394/1018594-46394938.pdf?src-supplier=Element14; ComponentLink3Description: https://datasheet.ciiva.com/12577/pyu-rc0402-51-rohs-l-6-r-12577743.pdf?src-supplier=Mouser; ComponentLink3URL: https://datasheet.ciiva.com/12577/pyu-rc0402-51-rohs-l-6-r-12577743.pdf?src-supplier=Mouser; Construction: Rectangular; Depth: 0.5mm; Features: Moisture Resistant; Height: 0.35mm; Lead Free: Lead Free; Length: 1mm; Library Name: Yageo.SchLib; Manufacturer 1: Yageo; Manufacturer Part Number 1: RC0402FR-0730KL; Max Operating Temperature: 155°C; Military Standard: Not; Min Operating Temperature: -55°C; Number of Terminations: 2; Packaging: Tape and Reel; Pricing 1: 1=0.10000, 10=0.01600, 100=0.00660, 1000=0.00299, 2500=0.00259, 5000=0.00214 (USD); REACH SVHC: Unknown; Resistor Type: Thick Film; RoHS: Yes; RoHS Compliant: Yes; Series: RC; Size Code: 0402; Supplier 1: Digi-Key; Supplier 2: Farnell; Supplier 3: Mouser; Supplier Part Number 1: 311-30.0KLRCT-ND; Supplier Part Number 2: 1458778RL; Supplier Part Number 3: 603-RC0402FR-0730KL; Termination: SMD/SMT; Tolerance: 1%; Voltage Rating (DC): 50V; Weight: 2.3E-05oz; Width: 0.5mm
R3 R4 = RC0402JR-075K1L: RES SMD 5.1K OHM 5% 1/16W 0402; Manufacturer: Yageo; Max Power Dissipation: 63mW; Power Rating: 62.5mW; Temperature Coefficient: 100ppm/°C; Voltage Rating: 50V; Case Code (Metric): 1005; Case/Package: 0402; Category: Chip SMD Resistors; Component Kind: Standard; ComponentLink2Description: Onnline datasheet; ComponentLink2URL: https://datasheet.ciiva.com/16740/pyu-rc0402-51-rohs-l-6-r-16740925.pdf; Construction: Rectangular; Depth: 0.5mm; Features: Moisture Resistant; Height: 0.35mm; Lead Free: Lead Free; Length: 1mm; Library Name: Yageo.SchLib; Manufacturer Part Number: RC0402JR-075K1L; Max Operating Temperature: 155°C; Military Standard: Not; Min Operating Temperature: -55°C; Number of Terminations: 2; Packaging: Tape and Reel; Pricing 1: 1=0.10000, 10=0.01300, 100=0.00520, 1000=0.00233, 2500=0.00202, 5000=0.00167 (USD); Pricing 2: 1=0.10000, 25=0.01000, 100=0.00400, 250=0.00400, 500=0.00300, 1000=0.00300 (USD); Pricing 3: 1=0.10000, 10=0.01000, 50=0.01000, 100=0.00400, 1000=0.00300, 10000=0.00200 (USD); Pricing 4: 10=0.00910, 100=0.00390, 500=0.00320, 2500=0.00240, 5000=0.00210, 10000=0.00190, 50000=0.00150 (GBP); Pricing 5: 10000=0.00270 (GBP); REACH SVHC: No SVHC; Resistor Type: Thick Film; RoHS: Yes; RoHS Compliant: Yes; Series: RC; Size Code: 0402; Stock 1: 1270619; Stock 2: 1265; Stock 3: 100817; Stock 4: 68890; Stock 5: 1265; Supplier 1: Digi-Key; Supplier 2: Newark; Supplier 3: Mouser; Supplier 4: Farnell; Supplier 5: Farnell; Supplier Part Number 1: 311-5.1KJRCT-ND; Supplier Part Number 2: 68R0016; Supplier Part Number 3: 603-RC0402JR-075K1L; Supplier Part Number 4: 3495714; Supplier Part Number 5: 1799293; Termination: SMD/SMT; Tolerance: 5%; Voltage Rating (DC): 50V; Weight: 2.3E-05oz; Width: 0.5mm; Checked By: ?; Created By: D. Gakure
R13 = RC0402FR-071KL: RES SMD 1K OHM 1% 1/16W 0402; Manufacturer: Yageo; Power Rating: 62.5mW; Temperature Coefficient: 100ppm/°C; Voltage Rating: 50V; Case Code (Imperial): 0402; Case Code (Metric): 1005; Case/Package: 0402; Category: Resistors; Component Kind: Standard; ComponentLink2Description: Online datasheet; ComponentLink2URL: https://datasheet.ciiva.com/12666/pyu-rc0402-51-rohs-l-6-r-12666741.pdf; Depth: 0.5mm; Height: 0.32mm; Lead Free: Lead Free; Length: 1mm; Library Name: Yageo.SchLib; Manufacturer Part Number: RC0402FR-071KL; Max Operating Temperature: 155°C; Military Standard: Not; Min Operating Temperature: -55°C; Number of Pins: 2; Packaging: Tape and Reel; Pricing 1: 1=0.10000, 10=0.01400, 100=0.00580, 1000=0.00262, 2500=0.00228, 5000=0.00188 (USD); Pricing 2: 1=0.10000, 10=0.01200, 100=0.00400, 1000=0.00400, 2500=0.00400, 10000=0.00300 (USD); Pricing 3: 1=0.08130, 25=0.01210, 100=0.01120, 250=0.01030, 500=0.00900 (GBP); REACH SVHC: Unknown; Resistor Type: Thick Film; RoHS: Yes; Stock 1: 37591306; Stock 2: 11912978; Stock 3: 29900; Supplier 1: Digi-Key; Supplier 2: Mouser; Supplier 3: Farnell; Supplier Part Number 1: 311-1.00KLRDKR-ND; Supplier Part Number 2: 603-RC0402FR-071KL; Supplier Part Number 3: 2132441; Termination: SMD/SMT; Tolerance: 1%; Voltage Rating (DC): 50V; Width: 0.5mm; Checked: ?; Created: D.G
R14 = RC0402FR-07220RL: RES SMD 220 OHM 1% 1/16W 0402; Manufacturer: Yageo; Max Power Dissipation: 63mW; Power Rating: 62.5mW; Temperature Coefficient: 100ppm/°C; Voltage Rating: 50V; Case Code (Metric): 1005; Case/Package: 0402; Category: Resistors; Component Kind: Standard; ComponentLink1Description: https://datasheet.ciiva.com/11819/0900766b80c66297-11819466.pdf?src-supplier=RSComponents; ComponentLink1URL: https://datasheet.ciiva.com/11819/0900766b80c66297-11819466.pdf?src-supplier=RSComponents; ComponentLink2Description: https://datasheet.ciiva.com/2453/pyu-r-int-thick-7-2453460.pdf?src-supplier=Digi-Key; ComponentLink2URL: https://datasheet.ciiva.com/2453/pyu-r-int-thick-7-2453460.pdf?src-supplier=Digi-Key; ComponentLink3Description: https://datasheet.ciiva.com/2453/pyu-r-int-thick-7-2453461.pdf?src-supplier=Digi-Key; ComponentLink3URL: https://datasheet.ciiva.com/2453/pyu-r-int-thick-7-2453461.pdf?src-supplier=Digi-Key; ComponentLink4Description: https://datasheet.ciiva.com/2453/pyu-r-int-thick-7-2453462.pdf?src-supplier=Digi-Key; ComponentLink4URL: https://datasheet.ciiva.com/2453/pyu-r-int-thick-7-2453462.pdf?src-supplier=Digi-Key; ComponentLink5Description: https://datasheet.ciiva.com/16746/pyu-rc0402-51-rohs-l-6-r-16746831.pdf?src-supplier=Future+Electronics; ComponentLink5URL: https://datasheet.ciiva.com/16746/pyu-rc0402-51-rohs-l-6-r-16746831.pdf?src-supplier=Future+Electronics; Depth: 0.5mm; Features: Moisture Resistant; Height: 0.016inch; Lead Free: Lead Free; Length: 1mm; Library Name: Yageo.SchLib; Manufacturer Part Number: RC0402FR-07220RL; Max Operating Temperature: 155°C; Military Standard: Not; Min Operating Temperature: -55°C; Number of Pins: 2; Number of Terminations: 2; Package Quantity: 1; Packaging: Tape and Reel; Pricing 1: 1=0.10000, 10=0.01400, 100=0.00580, 1000=0.00262, 2500=0.00228, 5000=0.00188 (USD); Pricing 2: 1=0.10000, 10=0.01200, 100=0.00400, 1000=0.00400, 2500=0.00400, 10000=0.00300 (USD); Pricing 3: 1=0.08130, 25=0.01210, 100=0.01120, 250=0.01030, 500=0.00900 (GBP); REACH SVHC: No SVHC; Resistor Type: Thick Film; RoHS: Yes; Stock 1: 1286042; Stock 2: 324625; Stock 3: 18234; Supplier 1: Digi-Key; Supplier 2: Mouser; Supplier 3: Farnell; Supplier Part Number 1: 311-220LRCT-ND; Supplier Part Number 2: 603-RC0402FR-07220RL; Supplier Part Number 3: 2147864; Termination: SMD/SMT; Voltage Rating (DC): 50V; Width: 0.02inch; Checked: ?; Created: D.G
SW1 = EVQ-P42B3M: Switch Tactile N.O. SPST Button J-Bend 0.02A 15VDC 1.6N SMD Embossed T/R; Actuator Color: Natural; Contact Resistance: 100mR; Current Rating: 20mA; Electrical Life: 1000000Cycles; Illumination Type: Non-Illuminated; Max Voltage Rating (DC): 15V; Switch Function: Off-Mom; Switch Travel: 0.25mm; Voltage Rating (AC): 250V; Case/Package: SMD/SMT; Category: Tactile Switches; Component Kind: Standard; ComponentLink1Description: CIIVA datasheet; ComponentLink1URL: https://datasheet.ciiva.com/16439/atk0000ce18-16439727.pdf?src-supplier=Future+Electronics; ComponentLink2Description: datasheet (offline); Depth: 3mm; Length: 6.2mm; Library Name: Panasonic.SchLib; Manufacturer 3: Panasonic; Manufacturer Part Number: EVQ-P42B3M; Manufacturer Part Number 3: EVQ-P42B3M; Max Current Rating: 20mA; Max Operating Temperature: 85°C; Mechanical Life: 1000000Cycles; Min Operating Temperature: -40°C; Mount: Edge,Surface Mount; Operating Force: 1.6N; Orientation: Right Angle; Package Quantity: 1; Packaging: Tape and Reel; Pricing 3: 10=0.78800 (USD); Radiation Hardening: No; RoHS: Yes; RoHS Compliant: Yes; Series: EVQP4; Size: 3.5mm; Stock 3: 310; Supplier 1: Digi-Key; Supplier 2: Mouser; Supplier 3: RSComponents; Supplier Part Number 1: P15502CT-ND; Supplier Part Number 2: 667-EVQ-P42B3M; Supplier Part Number 3: 1250217; Termination: J-Bend; Throw Configuration: SPST; Voltage Rating (DC): 15V; ComponentLink2URL: Y:\System\Altium\Libraries 2014\Panasonic\datasheets\EVQ-P4.pdf
TP2-TP6 = TestPoint: Test Point; Library Name: generic.SchLib
U1 = NCP114ASN330T1G: ON SEMICONDUCTOR - NCP114ASN330T1G - LDO Voltage Regulator, Fixed, 1.7 V to 5.5 V in, 3.3 V/300 mA out, 150 mV drop, TSOP-5; Dropout Voltage: 225mV; Max Input Voltage: 5.5V; Min Output Voltage: 3.3V; Number of Regulators: 1; Output Configuration: Positive; Output Current: 300mA; Power Supply Rejection Ratio (PSRR): 75dB; Quiescent Current: 95uA; Case/Package: SOT-23-5; Category: Voltage Regulators - Linear; Component Kind: Standard; ComponentLink3Description: On Semi datasheet online; ComponentLink3URL: http://www.onsemi.com/pub/Collateral/NCP114-D.PDF; Lead Free: Lead Free; Library Name: OnSemiconductor.SchLib; Manufacturer: ON Semiconductor; Manufacturer Part Number: NCP114ASN330T1G; Max Operating Temperature: 85°C; Min Operating Temperature: -40°C; Mount: Surface Mount; Output Type: Fixed; Packaging: Cut Tape; Pricing 1: 1=0.33000, 10=0.24900, 25=0.21760, 100=0.12440, 250=0.11664, 500=0.09330, 1000=0.07153 (USD); RoHS: No; Stock 1: 32529; Supplier 1: Digi-Key; Supplier 2: RSComponents; Supplier 3: Mouser; Supplier Part Number 1: NCP114ASN330T1GOSCT-ND; Supplier Part Number 2: 1263178; Supplier Part Number 3: 863-NCP114ASN330T1G; Checked: ?; Created: DG
U2 U3 U5 = TPS2553DBVR_ALT: POWER LOAD SWITCH, HIGH SIDE, SOT-23-6; Power Load Switch Type:High Side; Input Voltage:6.5V; Current Limit:1.7A; On State Resistance:0.085ohm; Distribution Switch Case Style:SOT-23; No. of Pins:6; Thermal Protection:Yes; On / Enable;RoHS Compliant: Yes; Manufacturer 1: Texas Instruments; Max Input Voltage: 6.5V; Max Power Dissipation: 625mW; Min Input Voltage: 2.5V; Number of Outputs: 1; On-State Resistance: 85mR; Output Current: 1.5A; Case/Package: SOT-23; Category: Hot Swap Controllers; Component Kind: Standard; ComponentLink1Description: https://datasheet.ciiva.com/15108/getdatasheetpartid-297517-15108509.pdf?src-supplier=Verical; ComponentLink1URL: https://datasheet.ciiva.com/15108/getdatasheetpartid-297517-15108509.pdf?src-supplier=Verical; Lead Free: Lead Free; Library Name: TexasInstruments.SchLib; Max Operating Temperature: 85°C; Max Supply Voltage: 6.5V; Min Operating Temperature: -40°C; Min Supply Voltage: 2.5V; Number of Pins: 6; Package Quantity: 1; Packaging: Tape and Reel; Pricing 1: 1=1.20000, 10=1.07100, 25=1.01640, 100=0.78050, 250=0.68972, 500=0.65340, 1000=0.50820 (USD); Radiation Hardening: No; REACH SVHC: No SVHC; RoHS: Yes; Stock 1: 14713; Supplier 1: Digi-Key; Supplier 2: Farnell; Supplier 3: Mouser; Supplier Part Number 1: 296-32464-1-ND; Supplier Part Number 2: 3124082; Supplier Part Number 3: 595-TPS2553DBVR; Weight: 0.00127oz
U4 = BM833: Category: RF Receivers, Transceivers; Component Kind: Standard; ComponentLink1Description: Datasheet (offline); ComponentLink2Description: Datasheet (online); ComponentLink2URL: https://www.fanstel.com/s/BM833_datasheets-rs9l.pdf; Description: Fanstel Nordic nRF52833 module; Library Name: Fanstel.SchLib; Manufacturer Part Number 1: BM833; Pricing 1: 1=10.43000, 10=9.55900, 25=8.69000, 100=7.82100, 250=7.16924, 500=6.51750 (USD); RoHS: No; Stock 1: 879; Supplier 1: Digi-Key; Supplier 2: Mouser; Supplier Part Number 1: 1914-BM833CT-ND; Supplier Part Number 2: 308-BM833; ComponentLink1URL: Y:\System\Altium\Libraries 2014\Fanstel\Datasheets\BM833_datasheets.pdf
